# Altium schematic sheet DP_UART_RVA.SchDoc
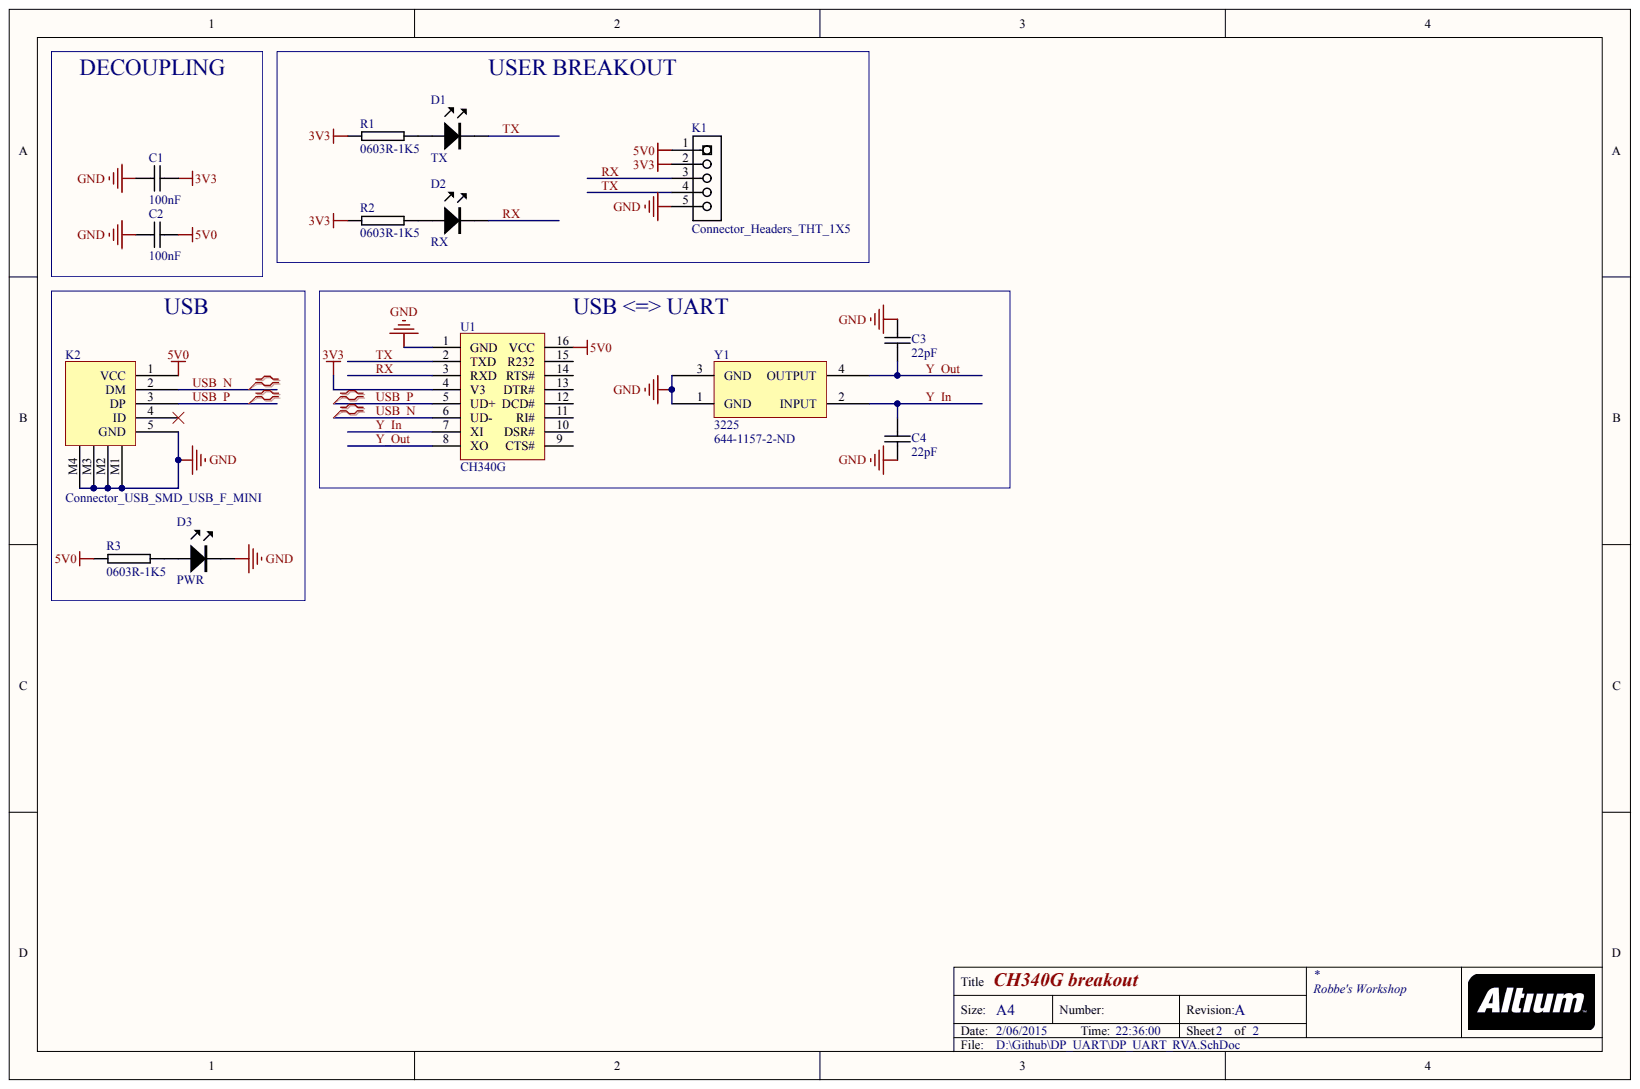
[diagram p0: DP_UART_RVA.SchDoc]

## Parts
C1  100nF  [0603C-GENERIC]
C2  100nF  [0603C-GENERIC]
C3  22pF  [0603C-GENERIC]
C4  22pF  [0603C-GENERIC]
D1  TX  [0805LED]
D2  RX  [0805LED]
D3  PWR  [0805LED]
K1  Connector_Headers_THT_1X5  [HEADER-1X5]
K2  Connector_USB_SMD_USB_F_MINI  [USB_F_MINI]
R1  0603R-1K5  [0603R-1k5]
R2  0603R-1K5  [0603R-1k5]
R3  0603R-1K5  [0603R-1k5]
U1  CH340G  [SO16]
Y1  3225  Supplier Part Number 1: 644-1157-2-ND  [XTAL 3225]

## Nets
3V3: C1.2 K1.2 R1.1 R2.1 U1.4(V3)
5V0: C2.2 K1.1 K2.1(VCC) R3.1 U1.16(VCC)
GND: C1.1 C2.1 C3.1 C4.2 D3.K K1.5 K2.5(GND) K2.M1 K2.M2 K2.M3 K2.M4 U1.1(GND) Y1.1(GND) Y1.3(GND)
RX: D2.K K1.3 U1.3(RXD)
TX: D1.K K1.4 U1.2(TXD)
USB_N: K2.2(DM) U1.6(UD-)
USB_P: K2.3(DP) U1.5(UD+)
Y_IN: C4.1 U1.7(XI) Y1.2(INPUT)
Y_OUT: C3.2 U1.8(XO) Y1.4(OUTPUT)
NetD1_A: D1.A R1.2
NetD2_A: D2.A R2.2
NetD3_A: D3.A R3.2

Not connected: K2 4(ID); U1 9(CTS#) 10(DSR#) 11(RI#) 12(DCD#) 13(DTR#) 14(RTS#) 15(R232)

## Library data of the parts
C1 C2 = Passives_Capacitors_0603_GENERIC: CAPACITOR 0603 CERAMIC GENERIC; Component Kind: Standard; Library Name: RVA_Altium_Library.IntLib
C3 C4 = Passives_Capacitors_0603_22PF-50V-C0G-PAN: CAPACITOR 0603 CERAMIC; Manufacturer: Panasonic - ECG; Manufacturer Part Number: ECJ-1VC1H220J; Reel: C10; Temperature Coefficient: C0G, NP0; Tolerance: ±5%; Voltage - Rated: 50V; Capacitance: 22pF; Category: Capacitors; Component Kind: Standard; Features: General Purpose; Lead Spacing: -; Mounting Type: Surface Mount, MLCC; Operating Temperature: -55°C ~ 125°C; Package / Case: 0603 (1608 metric); Packaging: Tape & Reel (TR); RoHS: RoHS Compliant; Supplier: Digi-Key; Supplier 1: Digi-Key; Supplier Part Number: PCC220ACVTR-ND; Supplier Part Number 1: PCC220ACVTR-ND; Thickness: 0.80mm; Library Name: RVA_Altium_Library.IntLib
D1-D3 = LED_SMD_0805: Component Kind: Standard; Library Name: RVA_Altium_Library.IntLib
K1 = Connector_Headers_THT_1X5: PINHEADER 1X5; REEL: TH; Component Kind: Standard; Supplier 1: Digi-Key; Supplier Part Number 1: S1011E-36-ND; Library Name: RVA_Altium_Library.IntLib
K2 = Connector_USB_SMD_USB_F_MINI: MINI USB B FEMALE; Component Kind: Standard; Library Name: RVA_Altium_Library.IntLib
R1-R3 = 0603R-1K5: GENERIC RESISTOR 0603; Manufacturer: Stackpole Electronics Inc; Manufacturer Part Number: RMCF 1/16 15K 1% R; Power (Watts): 0.1W, 1/10W; Resistance In Ohms: 15.0K; Temperature Coefficient: ±100ppm/°C; Tolerance: ±1%; Case: 0603 (1608 metric); Category: Resistors; Component Kind: Standard; Composition: Thick Film; Lead Style: Surface Mount (SMD - SMT); Packaging: Tape & Reel (TR); RoHS: RoHS Compliant; Supplier: Digi-Key; Supplier 1: Digi-Key; Supplier Part Number: RMCF1/1615KFRTR-ND; Supplier Part Number 1: RMCF1/1615KFRTR-ND; Library Name: RVA_Passives_Resistors_0603.SchLib
Y1 = 3225 Quartz: Component Kind: Standard; Supplier 1: Digi-Key; Library Name: RVA_Reference_Crystal Oscillator_SMD.SchLib
U1 = CH340G: Component Kind: Standard; Library Name: CH340.SchLib
